# Altium schematic sheet ESP-32.SchDoc
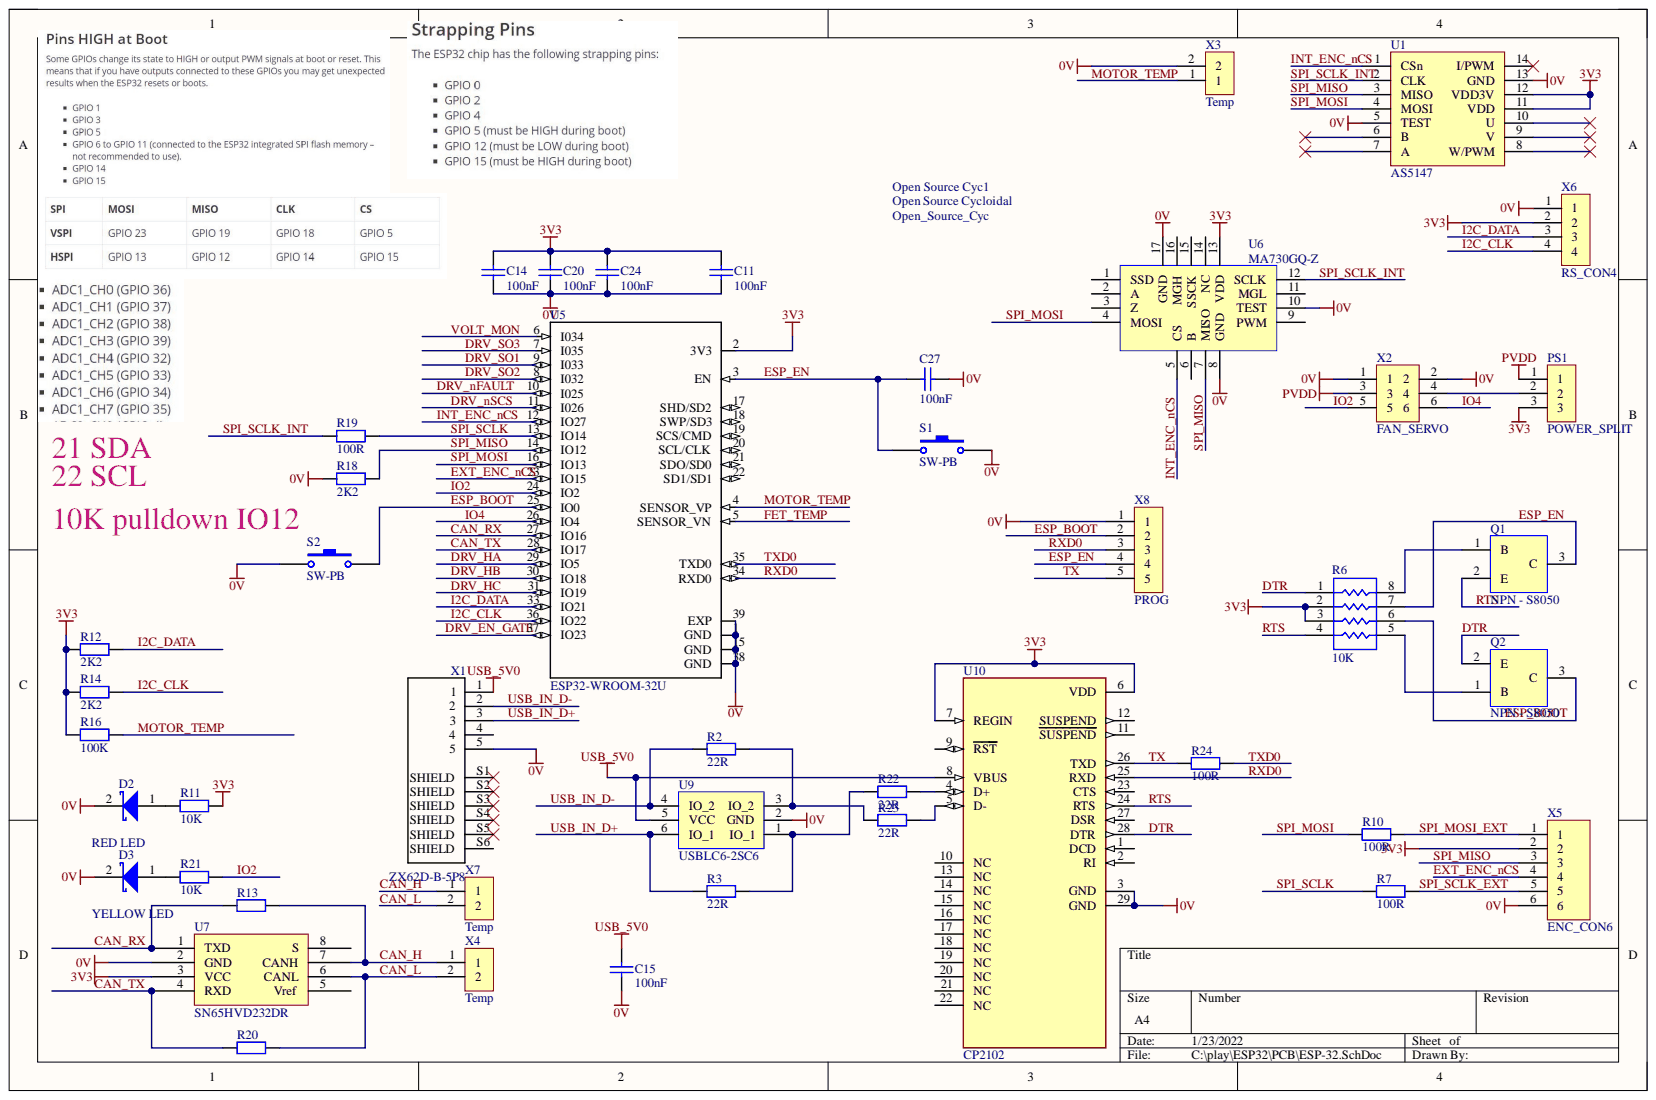
[diagram p0: ESP-32.SchDoc]

## Parts
C11  value 100nF  [0402]  (hidden comment: C14663)
C14  value 100nF  [0402]  (hidden comment: C14663)
C15  value 100nF  [0402]  (hidden comment: C14663)
C20  value 100nF  [0402]  (hidden comment: C14663)
C24  value 100nF  [0402]  (hidden comment: C14663)
C27  value 100nF  [0402]  (hidden comment: C14663)
D2  value RED LED  [0603D]  (hidden comment: C72041)
D3  value YELLOW LED  [0603D]  (hidden comment: C72038)
Open_Source_Cyc1  Open_Source_Cyc  [open_source_cyc10]
PS1  POWER_SPLIT  [POWER_SPLIT]
Q1  NPN - S8050  [SOT-23]
Q2  NPN - S8050  [SOT-23]
R2  value 22R  [0603R]  (hidden comment: C23345)
R3  value 22R  [0603R]  (hidden comment: C23345)
R6  10K  [R4_ARRAY]
R7  value 100R  [0402R]  (hidden comment: C22775)
R10  value 100R  [0402R]  (hidden comment: C22775)
R11  value 10K  [0402R]  (hidden comment: C25804)
R12  value 2K2  [0402R]  (hidden comment: C4190)
R13  (no value)  [0402]
R14  value 2K2  [0402R]  (hidden comment: C4190)
R16  value 100K  [0402R]  (hidden comment: C25803)
R18  value 2K2  [0402R]  (hidden comment: C4190)
R19  value 100R  [0402R]  (hidden comment: C22775)
R20  (no value)  [0402]
R21  value 10K  [0402R]  (hidden comment: C25804)
R22  value 22R  [0402R]  (hidden comment: C23345)
R23  value 22R  [0402R]  (hidden comment: C23345)
R24  value 100R  [0402R]  (hidden comment: C22775)
S1  SW-PB  [SW_B3U-1000P]
S2  SW-PB  [SW_B3U-1000P]
U1  value AS5147  [SOP14]
U5  ESP32-WROOM-32U  [*XCVR_ESP32-WROOM-32U]
U6  value MA730GQ-Z  [QFN-16]  (hidden comment: C161798)
U7  value SN65HVD232DR  [SO-8]  (hidden comment: C30530)
U9  value USBLC6-2SC6  [SOP6a]  (hidden comment: C7519-)
U10  CP2102  [QFN50P500X500X100-29N]
X1  ZX62D-B-5P8  [HRS_ZX62D-B-5P8_ZX62D-B-5P8(Primary)]
X2  FAN_SERVO  [CAN_COMM]
X3  Temp  [JST_SM_2]
X4  Temp  [JST_SM_2]
X5  ENC_CON6  [JST_SM06B-SRSS-TB]
X6  RS_CON4  [JST_SM04B-SRSS-TB]
X7  Temp  [JST_SM_2]
X8  PROG  [CON5_PROG_SMT]

## Nets
0V: C11.2 C14.2 C15.2 C20.2 C24.2 C27.2 D2.2 D3.2 R18.2 S1.2 S2.1 U1.5(TEST) U1.13(GND) U5.1(GND) U5.15(GND) U5.38(GND) U5.39(EXP) U6.8(GND) U6.10(TEST) U6.17(GND) U7.2(GND) U9.2(GND) U10.3(GND) U10.29(GND) X1.5 X2.1 X2.2 X3.2 X5.6 X6.1 X8.1
3V3: C11.1 C14.1 C20.1 C24.1 PS1.3 R6.2 R6.3 R11.1 R12.2 R14.2 R16.2 U1.11(VDD) U1.12(VDD3V) U5.2(3V3) U6.13(VDD) U7.3(VCC) U10.6(VDD) U10.7(REGIN) X5.2 X6.2
PVDD: PS1.1 X2.3
USB_5V0: C15.1 U9.5(VCC) U10.8(VBUS) X1.1
CAN_H: R13.1 U7.7(CANH) X4.1 X7.1
CAN_L: R20.1 U7.6(CANL) X4.2 X7.2
CAN_RX: R13.2 U5.27(IO16) U7.1(TXD)
CAN_TX: R20.2 U5.28(IO17) U7.4(RXD)
DRV_EN_GATE: U5.37(IO23)
DRV_HA: U5.29(IO5)
DRV_HB: U5.30(IO18)
DRV_HC: U5.31(IO19)
DRV_nFAULT: U5.10(I025)
DRV_nSCS: U5.11(I026)
DRV_SO1: U5.9(I033)
DRV_SO2: U5.8(I032)
DRV_SO3: U5.7(I035)
DTR: Q2.2 R6.1 U10.28(DTR)
ESP_BOOT: Q2.3 R6.6 S2.2 U5.25(IO0) X8.2
ESP_EN: C27.1 Q1.3 R6.7 S1.1 U5.3(EN) X8.4
EXT_ENC_nCS: U5.23(IO15) X5.4
FET_TEMP: U5.5(SENSOR_VN)
I2C_CLK: R14.1 U5.36(IO22) X6.4
I2C_DATA: R12.1 U5.33(IO21) X6.3
INT_ENC_nCS: U1.1(CSn) U5.12(IO27) U6.5(CS)
IO2: R21.1 U5.24(IO2) X2.5
IO4: U5.26(IO4) X2.6
MOTOR_TEMP: R16.1 U5.4(SENSOR_VP) X3.1
RTS: Q1.2 R6.4 U10.24(RTS)
RXD0: U5.34(RXD0) U10.25(RXD) X8.3
SPI_MISO: R18.1 U1.3(MISO) U5.14(IO12) U6.7(MISO) X5.3
SPI_MOSI: R10.1 U1.4(MOSI) U5.16(IO13) U6.4(MOSI)
SPI_MOSI_EXT: R10.2 X5.1
SPI_SCLK: R7.1 R19.2 U5.13(IO14)
SPI_SCLK_EXT: R7.2 X5.5
SPI_SCLK_INT: R19.1 U1.2(CLK) U6.12(SCLK)
TX: R24.1 U10.26(TXD) X8.5
TXD0: R24.2 U5.35(TXD0)
USB_IN_D+: R3.1 U9.6(IO_1) X1.3
USB_IN_D-: R2.1 U9.4(IO_2) X1.2
VOLT_MON: U5.6(I034)
NetD2_1: D2.1 R11.2
NetD3_1: D3.1 R21.2
NetPS1_2: PS1.2 X2.4
NetQ1_1: Q1.1 R6.8
NetQ2_1: Q2.1 R6.5
NetR2_2: R2.2 R23.1 U9.3(IO_2)
NetR3_2: R3.2 R22.1 U9.1(IO_1)
NetR22_2: R22.2 U10.4(D+)
NetR23_2: R23.2 U10.5(D-)

Not connected: U1 6(B) 7(A) 8(W/PWM) 9(V) 10(U) 14(I/PWM); U5 17(SHD/SD2) 18(SWP/SD3) 19(SCS/CMD) 20(SCL/CLK) 21(SDO/SD0) 22(SD1/SD1); U6 1(SSD) 2(A) 3(Z) 6(B) 9(PWM) 11(MGL) 14(NC) 15(SSCK) 16(MGH); U7 5(Vref) 8(S); U10 1(DCD) 2(RI) 9(RST) 10(NC) 11(SUSPEND) 12(SUSPEND) 13(NC) 14(NC) 15(NC) 16(NC) 17(NC) 18(NC) 19(NC) 20(NC) 21(NC) 22(NC) 23(CTS) 27(DSR); X1 4 S1(SHIELD) S2(SHIELD) S3(SHIELD) S4(SHIELD) S5(SHIELD) S6(SHIELD)

## Library data of the parts
C11 C14 C15 C20 C24 C27 = Cap: Capacitor; Component Kind: Standard; LatestRevisionDate: 17-Jul-2002; LatestRevisionNote: Re-released for DXP Platform.; Library Name: Miscellaneous Devices.IntLib; PackageDescription: Radial Cap, Thru-Hole; 2 Leads; 0.3 in Pin Spacing; PackageReference: RAD-0.3; Published: 8-Jun-2000; Publisher: Altium Limited
D2 = D Schottky: Schottky Diode; Value: RED LED; Code_JEDEC: DO-214-AA; Component Kind: Standard; LatestRevisionDate: 17-Jul-2002; LatestRevisionNote: Re-released for DXP Platform.; Library Name: Miscellaneous Devices.IntLib; PackageDescription: DO-214-AA/SMB; 2 C-Bend Leads; Body 5.3 x 3.6 mm, inc. leads (LxW); PackageReference: SMB; Published: 8-Jun-2000; Publisher: Altium Limited
D3 = D Schottky: Schottky Diode; Code_JEDEC: DO-214-AA; Component Kind: Standard; LatestRevisionDate: 17-Jul-2002; LatestRevisionNote: Re-released for DXP Platform.; Library Name: Miscellaneous Devices.IntLib; PackageDescription: DO-214-AA/SMB; 2 C-Bend Leads; Body 5.3 x 3.6 mm, inc. leads (LxW); PackageReference: SMB; Published: 8-Jun-2000; Publisher: Altium Limited
PS1 = CON3: Component Kind: Standard; Library Name: BLDC_LIB.SCHLIB
Q1 Q2 = NPN: Component Kind: Standard; Library Name: Brushless PSOC driver.SCHLIB
R2 R3 R7 R10-R14 R16 R18-R24 = Res2: Resistor; Component Kind: Standard; LatestRevisionDate: 17-Jul-2002; LatestRevisionNote: Re-released for DXP Platform.; Library Name: Miscellaneous Devices.IntLib; PackageDescription: Axial Device, Thru-Hole; 2 Leads; 0.4 in Pin Spacing; PackageReference: AXIAL-0.4; Published: 8-Jun-2000; Publisher: Altium Limited
R6 = EXB-38V223JV: RES ARRAY 22K OHM 5% 4 RES SMD; Manufacturer: Panasonic; Database Table Name: Resistors$; Fit: Yes; Component Kind: Standard; Manufacturer Part No#: EXB-38V223JV; Package Description: 3.2mm L x 1.6mm W x 0.5mm H; Published Date: 13/07/2009; RoHS Compliant: Yes; SMD or TH: SMD; Sub-Type: Array; Supplier1: Digikey; Supplier1PartNumber: Y9223CT-ND; Supplier1Price100USD: 0.0273; Supplier1Price1000USD: 0.01677; Supplier1PriceUSD: 0.031; Value: 10K; Checked By: PaulD; Library Name: Lazersafe.DbLib; Publisher: PaulD
S1 S2 = SW-PB: Switch; Component Kind: Standard; LatestRevisionDate: 17-Jul-2002; LatestRevisionNote: Re-released for DXP Platform.; Library Name: Miscellaneous Devices.IntLib; PackageDescription: Switch; 2 Leads; PackageReference: SPST-2; Published: 24-Mar-1999; Publisher: Altium Limited
U1 = as5147: Component Kind: Standard; Library Name: Schlib1.SchLib
U5 = ESP32-WROOM-32U: Bluetooth, WiFi 802.11b/g/n, Bluetooth v4.2 + EDR, Class 1, 2 and 3 Transceiver Module 2.4GHz ~ 2.5GHz Antenna Not Included, U.FL Surface Mount; MF: Espressif Systems; Availability: Unavailable; Component Kind: Standard; Library Name: ESP32-WROOM-32U.SchLib; MP: ESP32-WROOM-32U; Package: Module Espressif Systems; Price: None; SnapEDA_Link: https://www.snapeda.com/parts/ESP32-WROOM-32U/Espressif%20Systems/view-part/2472476/?ref=snap
U6 = MA730: Component Kind: Standard; Library Name: BLDC_LIB.SCHLIB
U7 = SN65HVD1050MDREP: Component Kind: Standard; Library Name: Brushless PSOC driver.SCHLIB
U9 = USBLC6-2: Component Kind: Standard; Library Name: BLDC_LIB.SCHLIB
U10 = CP2102: USB Bridge, USB to UART USB 2.0 UART Interface 28-QFN _5x5_; MF: Silicon Labs; Availability: Unavailable; Component Kind: Standard; MP: CP2102; Package: WFQFN-20 Silicon Labs; Price: None; SnapEDA_Link: https://www.snapeda.com/parts/CP2102/Silicon%20Labs/view-part/228557/?ref=snap; Library Name: Brushless PSOC driver.SCHLIB
X1 = ZX62D-B-5P8: SMD+DIP Micro USB; MICRO-B-SMD; MF: Hirose; Availability: Unavailable; Component Kind: Standard; MP: ZX62D-B-5P8; Package: None; Price: None; SnapEDA_Link: https://www.snapeda.com/parts/ZX62D-B-5P8/Hirose%20Electric%20Co%20Ltd/view-part/?ref=snap; Library Name: Brushless PSOC driver.SCHLIB
X2 = CAN_COMMS: Component Kind: Standard; Library Name: Brushless PSOC driver.SCHLIB
X3 X4 X7 = UART_CON2: Component Kind: Standard; Library Name: Brushless PSOC driver.SCHLIB
X5 = SPI_CON6: Component Kind: Standard; Library Name: Brushless PSOC driver.SCHLIB
X6 = UART_CON4: Component Kind: Standard; Library Name: Brushless PSOC driver.SCHLIB
X8 = PSOC_PROG: Component Kind: Standard; Library Name: Brushless PSOC driver.SCHLIB
Open_Source_Cyc1 = Open_Source_Cyc: Component Kind: Standard; Library Name: Brushless PSOC driver.SCHLIB
